# Altium schematic sheet Sheet2.SchDoc(Sheet2)
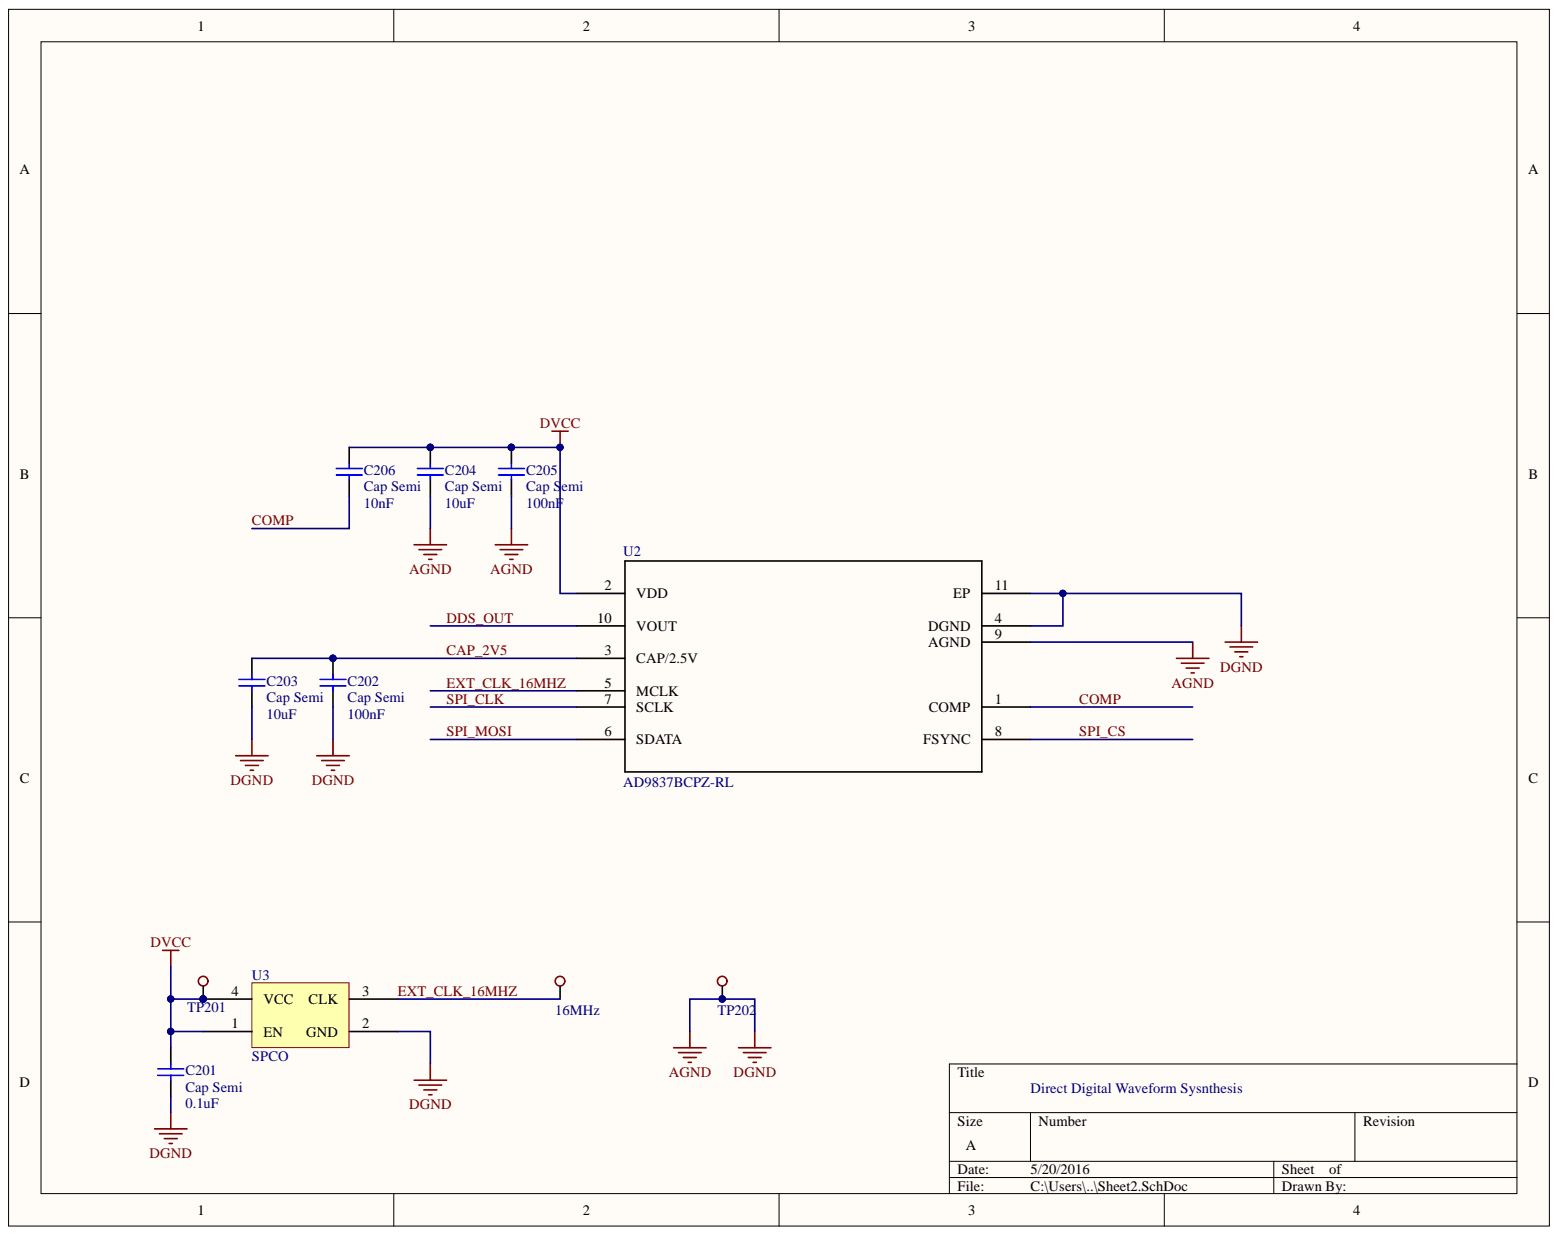
[diagram p0: Sheet2.SchDoc]

## Parts
16MHz  TEST_POINT  [TEST_POINT]  (value not drawn)
C201  Cap Semi  value 0.1uF  [1608[0603]]
C202  Cap Semi  value 100nF  [1608[0603]]
C203  Cap Semi  value 10uF  [1608[0603]]
C204  Cap Semi  value 10uF  [1608[0603]]
C205  Cap Semi  value 100nF  [1608[0603]]
C206  Cap Semi  value 10nF  [1608[0603]]
TP201  TEST_POINT  [TEST_POINT]  (value not drawn)
TP202  TEST_POINT  [TEST_POINT]  (value not drawn)
U2  AD9837BCPZ-RL  [CP_10_9-M]
U3  SPCO  [CRYSTAL]

## Nets
AGND: C201.1 C202.1 C203.1 C204.1 C205.1 TP202.0 U2.4(DGND) U2.9(AGND) U2.11(EP) U3.2(GND)
DVCC: C201.2 C204.2 C205.2 C206.2 TP201.0 U2.2(VDD) U3.1(EN) U3.4(VCC)
CAP_2V5: C202.2 C203.2 U2.3(CAP/2.5V)
COMP: C206.1 U2.1(COMP)
DDS_OUT: U2.10(VOUT)
EXT_CLK_16MHZ: 16MHz.0 U2.5(MCLK) U3.3(CLK)
SPI_CLK: U2.7(SCLK)
SPI_CS: U2.8(FSYNC)
SPI_MOSI: U2.6(SDATA)

## Library data of the parts
C201-C206 = Cap Semi: Capacitor (Semiconductor SIM Model); Component Kind: Standard; LatestRevisionDate: 29-May-2009; LatestRevisionNote: IPC-7351 Footprint Added.; Library Name: Miscellaneous Devices.IntLib; PackageDescription: Chip Capacitor; PackageReference: C1206; Published: 8-Jun-2000; Signal Integrity: Cap Semi; Simulation: CAP; Publisher: Altium Limited
U2 = AD9837BCPZ-RL: Imported; Component Kind: Standard; Library Name: Altium.SchLib; RefDes: RefDes; Signal Integrity: Guessed Model; TYPE: IC; Value: Value
U3 = SPCO: Simple Package Crystal Oscillator SPCO; Component Kind: Standard; Library Name: Altium.SchLib; Signal Integrity: Guessed Model
16MHz TP201 TP202 = TEST_POINT: Component Kind: Standard; Library Name: Altium.SchLib
  16MHz: Signal Integrity: Guessed Model
